# Altium schematic sheet Sheet1.SchDoc
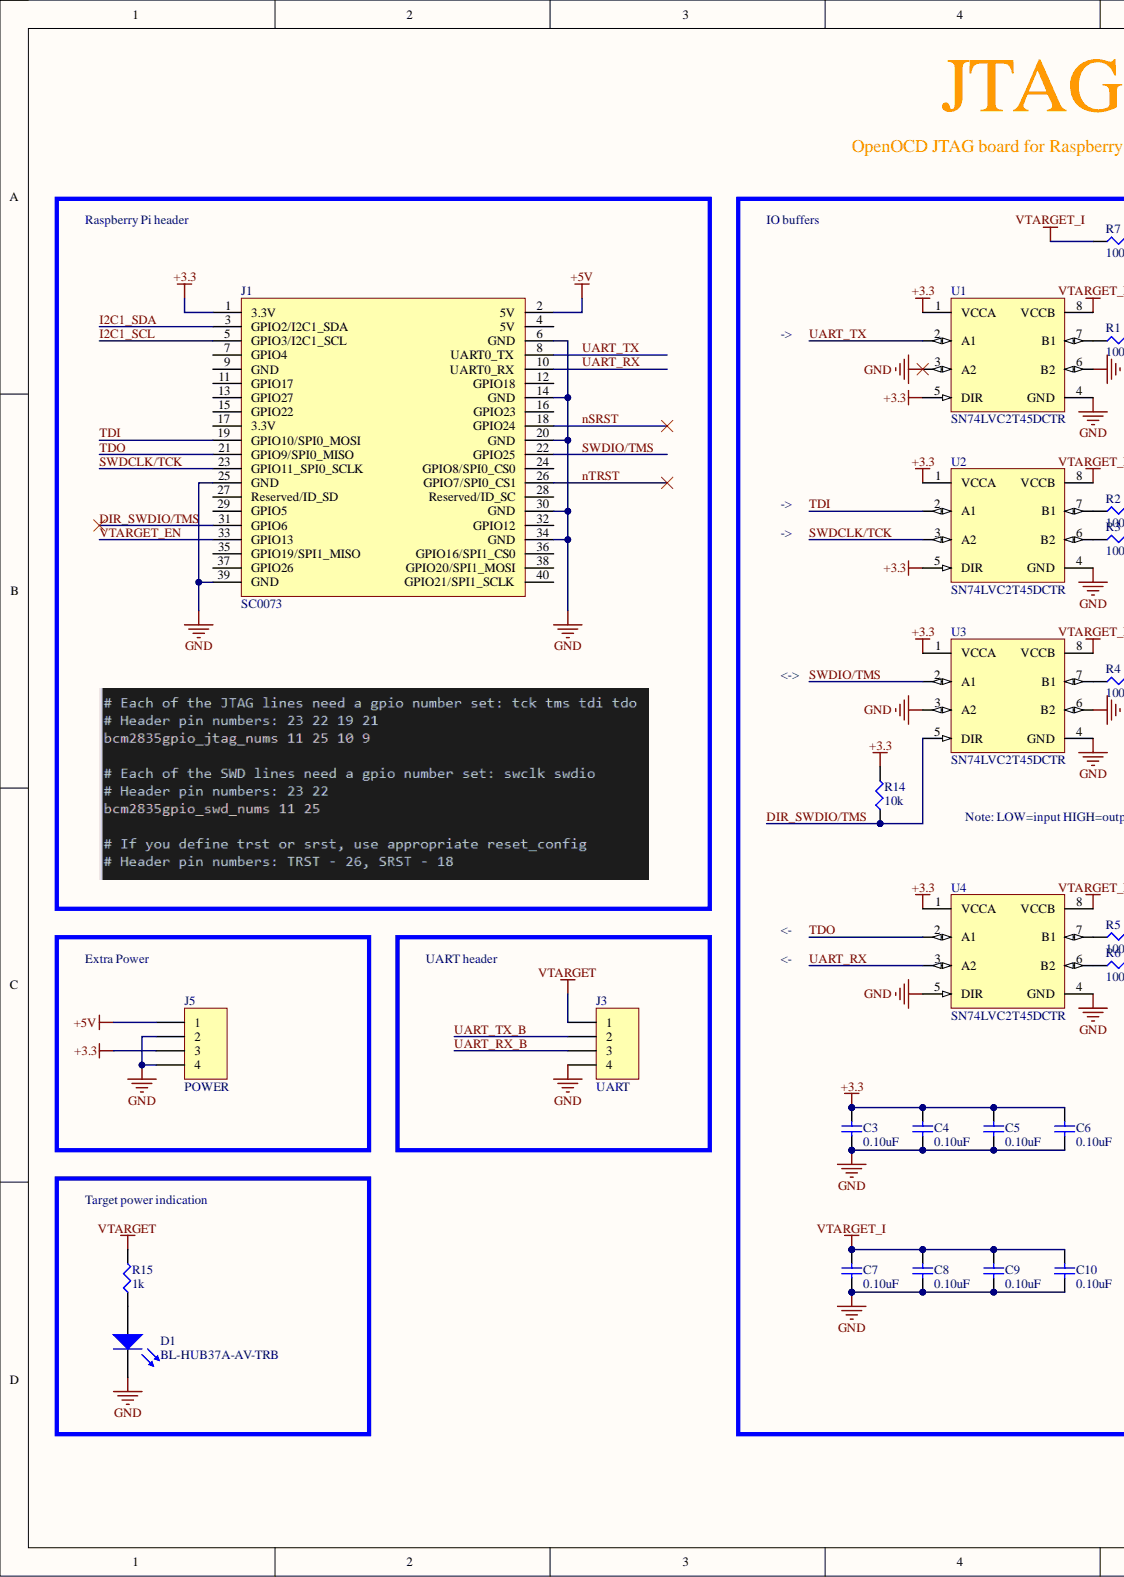
[diagram p0: Sheet1.SchDoc, left]
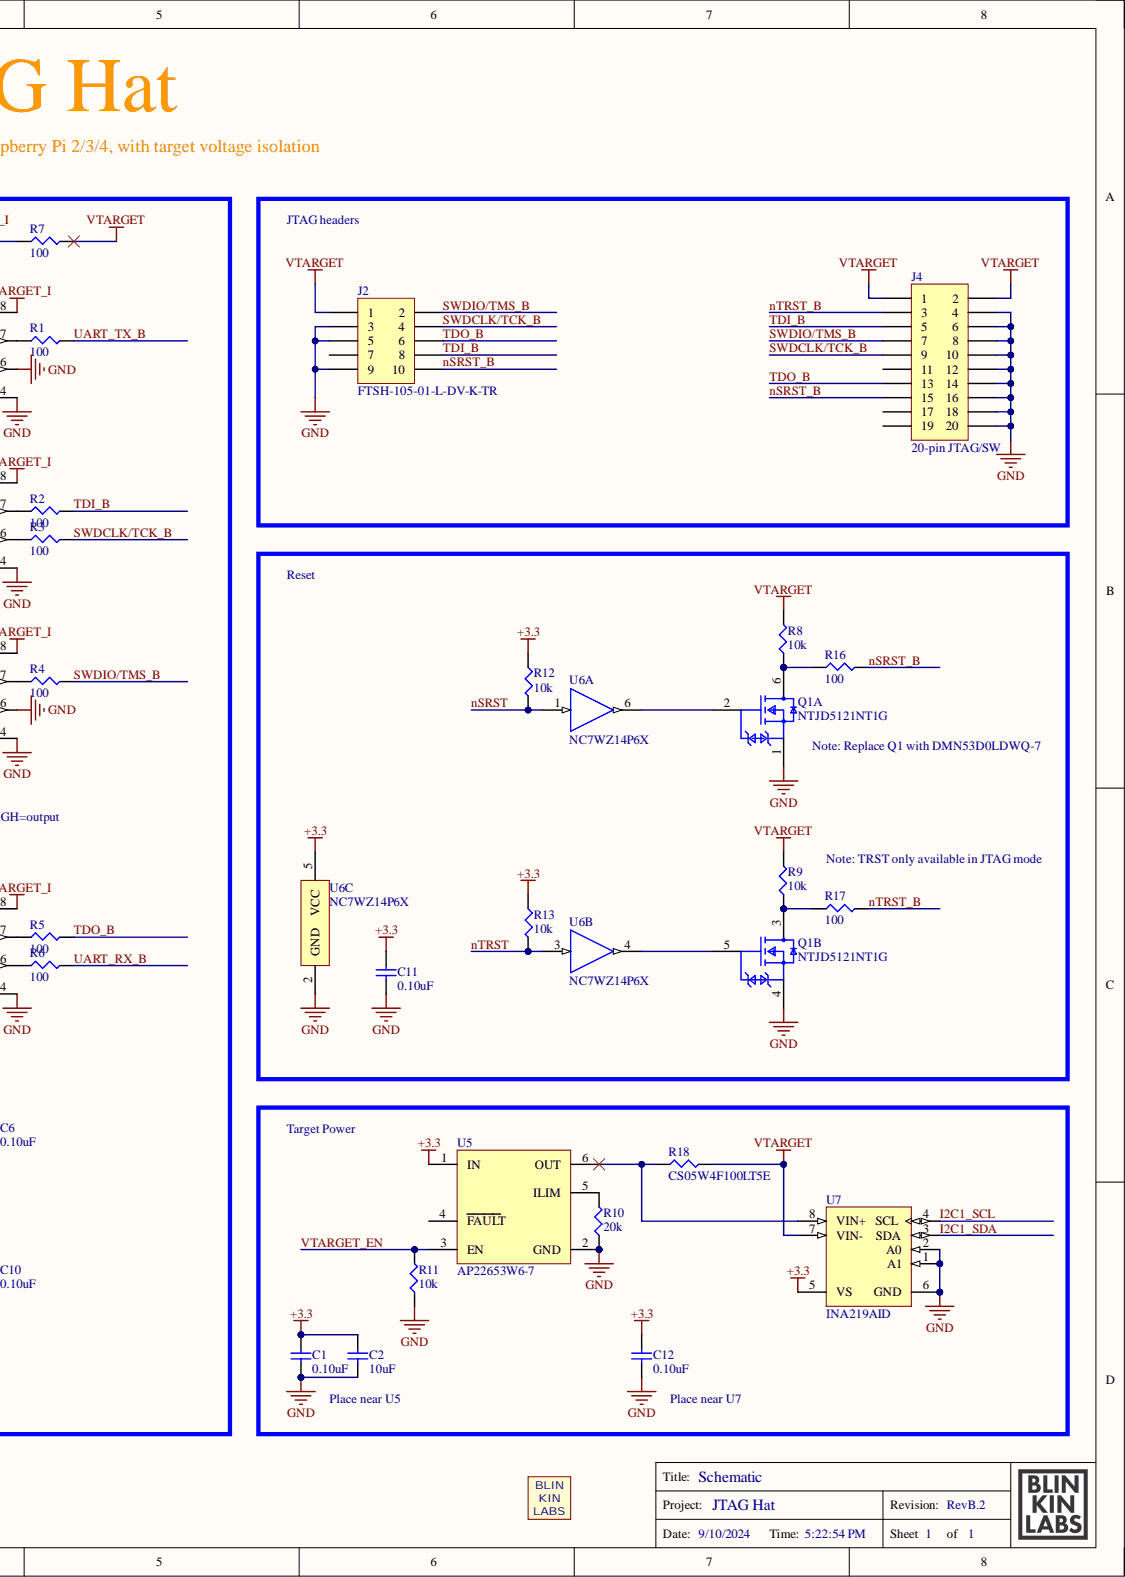
[diagram p1: Sheet1.SchDoc, right]

## Parts
C1  0.10uF  [CAPC1005X06L]
C2  10uF  [CAPC2012X10L]
C3  0.10uF  [CAPC1005X06L]
C4  0.10uF  [CAPC1005X06L]
C5  0.10uF  [CAPC1005X06L]
C6  0.10uF  [CAPC1005X06L]
C7  0.10uF  [CAPC1005X06L]
C8  0.10uF  [CAPC1005X06L]
C9  0.10uF  [CAPC1005X06L]
C10  0.10uF  [CAPC1005X06L]
C11  0.10uF  [CAPC1005X06L]
C12  0.10uF  [CAPC1005X06L]
D1  BL-HUB37A-AV-TRB  [BL-Hxx37A-AV-TRB]
J1  SC0073  [RaspberryPi_Connector]
J2  FTSH-105-01-L-DV-K-TR  [FTSH-105-01-L-DV-K-TR]
J3  UART  [HDR1X4]
J4  20-pin JTAG/SW  [HDR2X10]
J5  POWER  [HDR1X4]
L1  BLINKINLABS_LOGO  [BLINKINLABS_LOGO]  (value not drawn)  (no BOM)
Q1  NTJD5121NT1G  [FP-419B-02-MFG]  (parts A,B)
R1  100  [ERJ2G(0402)_L]
R2  100  [ERJ2G(0402)_L]
R3  100  [ERJ2G(0402)_L]
R4  100  [ERJ2G(0402)_L]
R5  100  [ERJ2G(0402)_L]
R6  100  [ERJ2G(0402)_L]
R7  100  [ERJ2G(0402)_L]
R8  10k  [ERJ2G(0402)_L]
R9  10k  [ERJ2G(0402)_L]
R10  20k  [ERJ2G(0402)_L]
R11  10k  [ERJ2G(0402)_L]
R12  10k  [ERJ2G(0402)_L]
R13  10k  [ERJ2G(0402)_L]
R14  10k  [ERJ2G(0402)_L]
R15  1k  [ERJ2G(0402)_L]
R16  100  [ERJ2G(0402)_L]
R17  100  [ERJ2G(0402)_L]
R18  CS05W4F100LT5E  [ERJ6G(0805)_N]
U1  SN74LVC2T45DCTR  [FP-DCT0008A-MFG]
U2  SN74LVC2T45DCTR  [FP-DCT0008A-MFG]
U3  SN74LVC2T45DCTR  [FP-DCT0008A-MFG]
U4  SN74LVC2T45DCTR  [FP-DCT0008A-MFG]
U5  AP22653W6-7  [SOT95P280X145-6N-5]
U6  NC7WZ14P6X  [TSOP65P210X110-6N]  (parts A,B,C)
U7  INA219AID  [D0008A_N]

## Nets
+3.3: C1.2 C2.1 C3.2 C4.2 C5.2 C6.2 C11.2 C12.2 J1.1(3.3V) J5.3 R12.1 R13.1 R14.2 U1.1(VCCA) U1.5(DIR) U2.1(VCCA) U2.5(DIR) U3.1(VCCA) U4.1(VCCA) U5.1(IN) U6.5(VCC) U7.5(VS)
+5V: J1.2(5V) J5.1
GND: C1.1 C2.2 C3.1 C4.1 C5.1 C6.1 C7.1 C8.1 C9.1 C10.1 C11.1 C12.1 D1.2 J1.6(GND) J1.14(GND) J1.20(GND) J1.25(GND) J1.30(GND) J1.34(GND) J1.39(GND) J2.3 J2.5 J2.9 J3.4 J4.4 J4.6 J4.8 J4.10 J4.12 J4.14 J4.16 J4.18 J4.20 J5.2 J5.4 Q1.1 Q1.4 R10.1 R11.1 U1.3(A2) U1.4(GND) U1.6(B2) U2.4(GND) U3.3(A2) U3.4(GND) U3.6(B2) U4.4(GND) U4.5(DIR) U5.2(GND) U6.2(GND) U7.1(A1) U7.2(A0) U7.6(GND)
VTARGET: J2.1 J3.1 J4.1 J4.2 R7.2 R8.2 R9.2 R15.2 R18.2 U7.7(VIN-)
VTARGET_I: C7.2 C8.2 C9.2 C10.2 R7.1 U1.8(VCCB) U2.8(VCCB) U3.8(VCCB) U4.8(VCCB)
DIR_SWDIO/TMS: J1.31(GPIO6) R14.1 U3.5(DIR)
I2C1_SCL: J1.5(GPIO3/I2C1_SCL) U7.4(SCL)
I2C1_SDA: J1.3(GPIO2/I2C1_SDA) U7.3(SDA)
nSRST: J1.18(GPIO24) R12.2 U6.1
nSRST_B: J2.10 J4.15 R16.2
nTRST: J1.26(GPIO7/SPI0_CS1) R13.2 U6.3
nTRST_B: J4.3 R17.2
SWDCLK/TCK: J1.23(GPIO11_SPI0_SCLK) U2.3(A2)
SWDCLK/TCK_B: J2.4 J4.9 R3.2
SWDIO/TMS: J1.22(GPIO25) U3.2(A1)
SWDIO/TMS_B: J2.2 J4.7 R4.2
TDI: J1.19(GPIO10/SPI0_MOSI) U2.2(A1)
TDI_B: J2.8 J4.5 R2.2
TDO: J1.21(GPIO9/SPI0_MISO) U4.2(A1)
TDO_B: J2.6 J4.13 R5.2
UART_RX: J1.10(UART0_RX) U4.3(A2)
UART_RX_B: J3.3 R6.2
UART_TX: J1.8(UART0_TX) U1.2(A1)
UART_TX_B: J3.2 R1.2
VTARGET_EN: J1.33(GPIO13) R11.2 U5.3(EN)
NetD1_1: D1.1 R15.1
NetQ1_2: Q1.2 U6.6
NetQ1_3: Q1.3 R9.1 R17.1
NetQ1_5: Q1.5 U6.4
NetQ1_6: Q1.6 R8.1 R16.1
NetR1_1: R1.1 U1.7(B1)
NetR2_1: R2.1 U2.7(B1)
NetR3_1: R3.1 U2.6(B2)
NetR4_1: R4.1 U3.7(B1)
NetR5_1: R5.1 U4.7(B1)
NetR6_1: R6.1 U4.6(B2)
NetR10_2: R10.2 U5.5(ILIM)
NetR18_1: R18.1 U5.6(OUT) U7.8(VIN+)

Not connected: J1 4(5V) 7(GPIO4) 9(GND) 11(GPIO17) 12(GPIO18) 13(GPIO27) 15(GPIO22) 16(GPIO23) 17(3.3V) 24(GPIO8/SPI0_CS0) 27(Reserved/ID_SD) 28(Reserved/ID_SC) 29(GPIO5) 32(GPIO12) 35(GPIO19/SPI1_MISO) 36(GPIO16/SPI1_CS0) 37(GPIO26) 38(GPIO20/SPI1_MOSI) 40(GPIO21/SPI1_SCLK); J2 7; J4 11 17 19; U5 4(FAULT)

## Library data of the parts
C1 C3-C12 = GRM155R61C104KA88D: CAP CER 0.1UF 16V X5R 0402; Manufacturer 1: Murata Electronics North America; Database Table Name: capacitor_murata_0402; Tolerance: ±10%; Case/Package: 0402 (1005 Metric); Component Kind: Standard; Manufacturer Part Number 1: GRM155R61C104KA88D; Part Name: GRM155R61C104KA88D; Supplier 1: DigiKey; Supplier Part Number 1: 490-5415-1-ND; Temperature Coefficient: X5R; Value: 0.10uF; Voltage: 16V; Library Name: blinkinlabs.DbLib
C2 = GRM21BR71A106KE51L: CAP CER 10UF 10V X7R 0805; Manufacturer 1: Murata Electronics North America; Database Table Name: capacitor_murata_0805; Tolerance: ±10%; Case/Package: 0805 (2012 Metric); Component Kind: Standard; Manufacturer Part Number 1: GRM21BR71A106KE51L; Part Name: GRM21BR71A106KE51L; Supplier 1: DigiKey; Supplier Part Number 1: 490-3905-1-ND; Temperature Coefficient: X7R; Value: 10uF; Voltage: 10V; Library Name: blinkinlabs.DbLib
D1 = BL-HUB37A-AV-TRB: LED, 0402, Super Red, 50mcd@20mA, 627~637nm; Manufacturer 1: BrtLed; Database Table Name: general; id: 80; Component Kind: Standard; Manufacturer Part Number 1: BL-HUB37A-AV-TRB; Part Name: BL-HUB37A-AV-TRB; Supplier 1: LCSC; Supplier Part Number 1: C165980; Value: BL-HUB37A-AV-TRB; Library Name: blinkinlabs.DbLib
J1 = SC0073: Raspberry Pi shield Header; Database Table Name: general; id: 245; Component Kind: Standard; Manufacturer 1: Raspberry Pi; Manufacturer Part Number 1: SC0073; Part Name: SC0073; Supplier 1: DigiKey; Supplier Part Number 1: 2648-SC0073-ND; Value: SC0073; Library Name: blinkinlabs.DbLib
J2 = FTSH-105-01-L-DV-K-TR: 1.27mm 2 10P -55℃~+125℃ Standing paste 3.4A SMD,P=1.27mm Board-to-Board and Backplane Connector ROHS; Manufacturer 1: Samtec; Database Table Name: general; id: 375; Component Kind: Standard; Manufacturer Part Number 1: FTSH-105-01-L-DV-K-TR; Part Name: FTSH-105-01-L-DV-K-TR; Supplier 1: LCSC; Supplier 2: DigiKey; Supplier Part Number 1: C448647; Supplier Part Number 2: SAM13165CT-ND; Value: FTSH-105-01-L-DV-K-TR; Library Name: blinkinlabs.DbLib
J3 J5 = Header 4: Header, 4-Pin; Component Kind: Standard; LatestRevisionDate: 17-Jul-2002; LatestRevisionNote: Re-released for DXP Platform.; Library Name: Miscellaneous Connectors.IntLib; Signal Integrity: Connector; Publisher: Altium Limited
J4 = Header 10X2: Component Kind: Standard; LatestRevisionDate: 17-Jul-2002; LatestRevisionNote: Re-released for DXP Platform.; Library Name: Miscellaneous Connectors.IntLib; Signal Integrity: Connector; Publisher: Altium Limited
L1 = BLINKINLABS_LOGO: Square logo; Library Name: Blinkinlabs.IntLib
Q1 = NTJD5121NT1G: Mosfet Array 60V 295mA 250mW Surface Mount SC-88/SC70-6/SOT-363; Manufacturer 1: onsemi; Database Table Name: general; id: 376; Case/Package: 6-TSSOP, SC-88, SOT-363; Component Kind: Standard; Manufacturer Case/Package 1: SC-88/SC70-6/SOT-363; Manufacturer Part Number 1: NTJD5121NT1G; Part Name: NTJD5121NT1G; Supplier 1: DigiKey; Supplier 2: LCSC; Supplier Part Number 1: NTJD5121NT1GOSCT-ND; Supplier Part Number 2: C15215; Value: NTJD5121NT1G; Library Name: blinkinlabs.DbLib
R1-R7 R16 R17 = ERJ-2GEJ101X: RES SMD 100 OHM 5% 1/10W 0402; Manufacturer 1: Panasonic Electronic Components; Database Table Name: Panasonic_ERJ_0402; Power (Watts): 0.1W, 1/10W; Case/Package: 0402 (1005 Metric); Component Kind: Standard; Manufacturer Part Number 1: ERJ-2GEJ101X; Part Name: ERJ-2GEJ101X; Supplier 1: Digikey; Supplier Part Number 1: P100JCT-ND; Tolerance: ±5%; Value: 100; Library Name: blinkinlabs.DbLib
R8 R9 R11-R14 = ERJ-PA2J103X: RES SMD 10K OHM 5% 1/5W 0402; Manufacturer 1: Panasonic Electronic Components; Database Table Name: Panasonic_ERJ_0402; Power (Watts): 0.2W, 1/5W; Case/Package: 0402 (1005 Metric); Component Kind: Standard; Manufacturer Part Number 1: ERJ-PA2J103X; Part Name: ERJ-PA2J103X; Supplier 1: Digikey; Supplier Part Number 1: P17199CT-ND; Tolerance: ±5%; Value: 10k; Library Name: blinkinlabs.DbLib
R10 = ERJ-2RKF2002X: RES SMD 20K OHM 1% 1/10W 0402; Manufacturer 1: Panasonic Electronic Components; Database Table Name: Panasonic_ERJ_0402; Power (Watts): 0.1W, 1/10W; Case/Package: 0402 (1005 Metric); Component Kind: Standard; Manufacturer Part Number 1: ERJ-2RKF2002X; Part Name: ERJ-2RKF2002X; Supplier 1: Digikey; Supplier Part Number 1: P20.0KLCT-ND; Tolerance: ±1%; Value: 20k; Library Name: blinkinlabs.DbLib
R15 = ERJ-2GEJ102X: RES SMD 1K OHM 5% 1/10W 0402; Manufacturer 1: Panasonic Electronic Components; Database Table Name: Panasonic_ERJ_0402; Power (Watts): 0.1W, 1/10W; Case/Package: 0402 (1005 Metric); Component Kind: Standard; Manufacturer Part Number 1: ERJ-2GEJ102X; Part Name: ERJ-2GEJ102X; Supplier 1: Digikey; Supplier Part Number 1: P1.0KJCT-ND; Tolerance: ±5%; Value: 1k; Library Name: blinkinlabs.DbLib
R18 = CS05W4F100LT5E: 250mW Thick Film Resistors 500V ±1% 100mΩ 0805 Chip Resistor - Surface Mount ROHS; Manufacturer 1: UNI-ROYAL; Manufacturer Case/Package 1: 0805; Database Table Name: general; id: 379; Component Kind: Standard; Manufacturer Part Number 1: CS05W4F100LT5E; Part Name: CS05W4F100LT5E; Supplier 1: LCSC; Supplier Part Number 1: C474291; Value: CS05W4F100LT5E; Library Name: blinkinlabs.DbLib
U1-U4 = SN74LVC2T45DCTR: Voltage Level Translator Bidirectional 1 Circuit 2 Channel 420Mbps SM8; Manufacturer 1: Texas Instruments; Database Table Name: general; id: 378; Case/Package: 8-LSSOP, 8-MSOP; Component Kind: Standard; Manufacturer Case/Package 1: SM8; Manufacturer Part Number 1: SN74LVC2T45DCTR; Part Name: SN74LVC2T45DCTR; Supplier 1: DigiKey; Supplier 2: LCSC; Supplier Part Number 1: 296-16845-1-ND; Supplier Part Number 2: C22672; Value: SN74LVC2T45DCTR; Library Name: blinkinlabs.DbLib
U5 = AP22653W6-7: LOAD SWITCH,SOT26,T&R,3K; Manufacturer 1: Diodes Incorporated; Database Table Name: general; id: 55; Component Kind: Standard; Manufacturer Part Number 1: AP22653W6-7; Part Name: AP22653W6-7; Supplier 1: DigiKey; Supplier 2: LCSC; Supplier Part Number 1: 31-AP22653W6-7TR-ND; Supplier Part Number 2: C2158037; Value: AP22653W6-7; Library Name: blinkinlabs.DbLib
U6 = NC7WZ14P6X: Inverter IC 2 Channel Schmitt Trigger SC-88 (SC-70-6); Manufacturer 1: onsemi; Database Table Name: general; id: 377; Case/Package: 6-TSSOP, SC-88, SOT-363; Component Kind: Standard; Manufacturer Case/Package 1: SC-88 (SC-70-6); Manufacturer Part Number 1: NC7WZ14P6X; Part Name: NC7WZ14P6X; Supplier 1: DigiKey; Supplier 2: LCSC; Supplier Part Number 1: NC7WZ14P6XCT-ND; Supplier Part Number 2: C19829571; Value: NC7WZ14P6X; Library Name: blinkinlabs.DbLib
U7 = INA219AID: Current Monitor Regulator High-Side 10mA 8-SOIC; Manufacturer 1: Texas Instruments; Database Table Name: general; id: 370; Case/Package: 8-SOIC; Component Kind: Standard; Manufacturer Part Number 1: INA219AID; Part Name: INA219AID; Supplier 1: DigiKey; Supplier Part Number 1: 296-33291-5-ND; Value: INA219AID; Library Name: blinkinlabs.DbLib
